# Altium schematic sheet schematic_C.SchDoc(schematic_C)
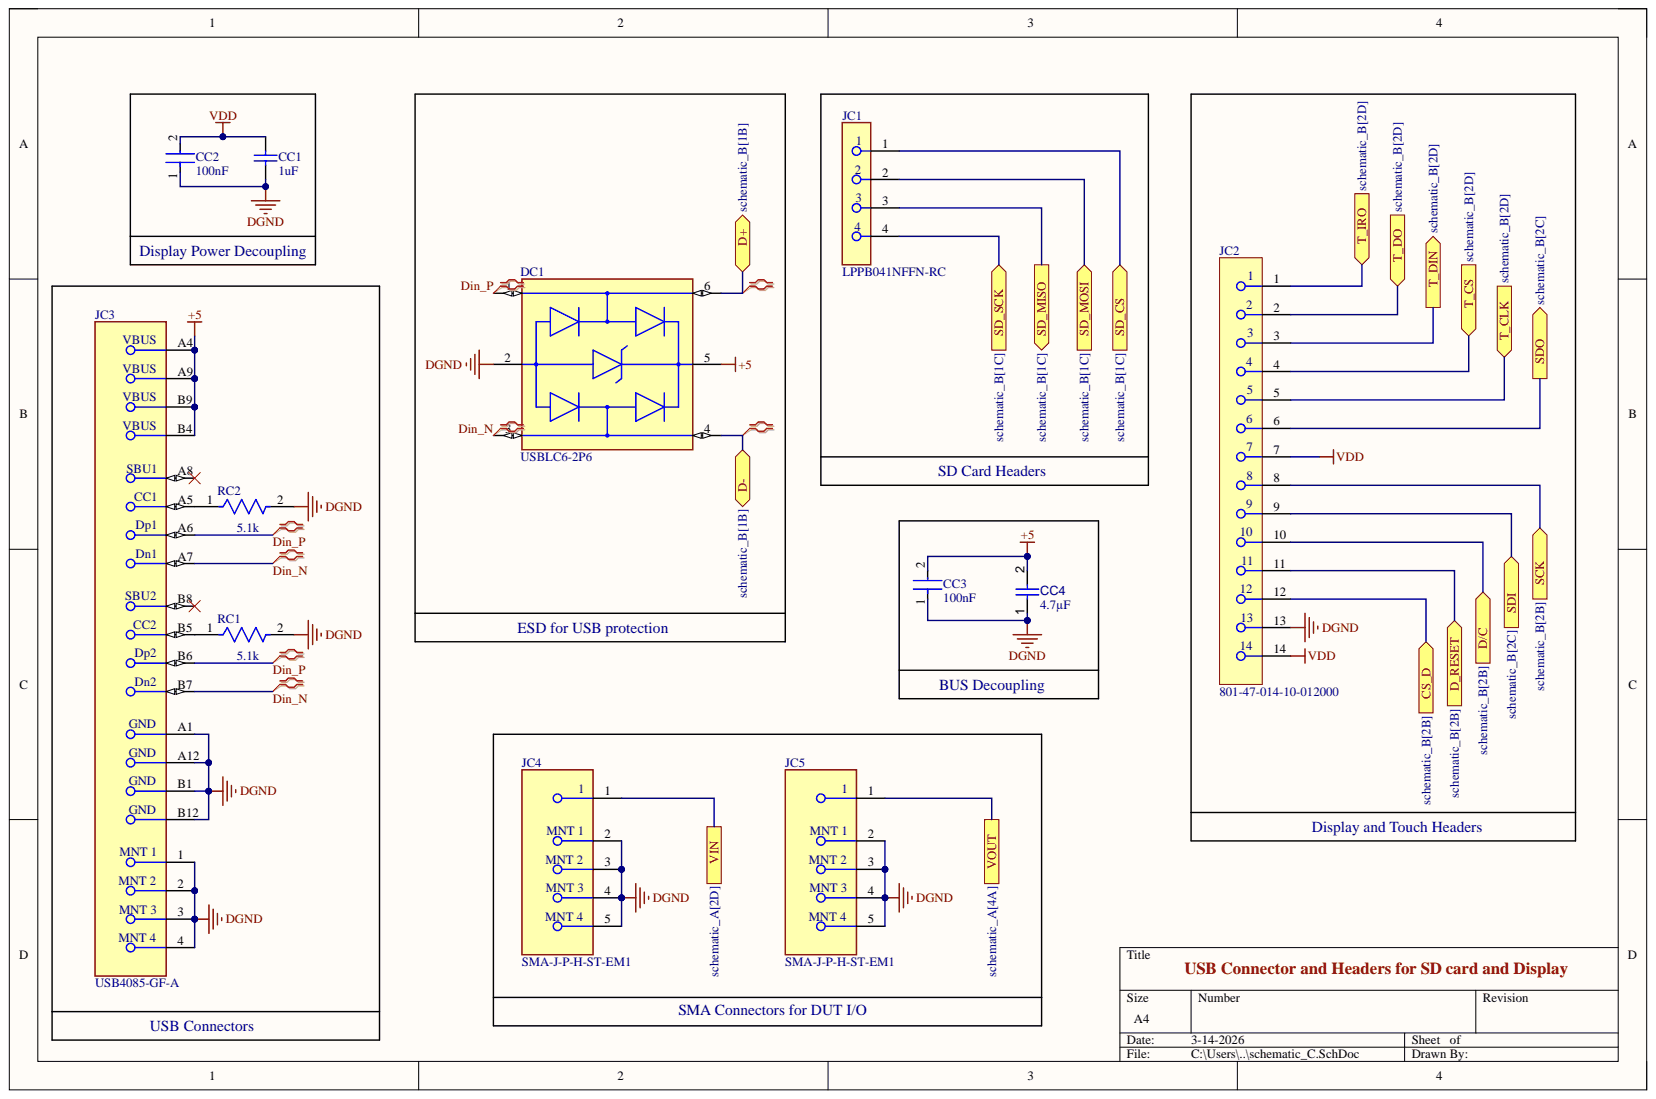
[diagram p0: schematic_C.SchDoc]

## Parts
CC1  1uF  [CAPC0603X33X15NL03T05]
CC2  100nF  [FP-0402-L_1_0_0_05-W_0_5-MFG]
CC3  100nF  [FP-0402-L_1_0_0_05-W_0_5-MFG]
CC4  value 4.7µF  [CAPC0805(2012)145_L]  (hidden comment: Capacitor 4.7µF +/-40% 25V 0805)
DC1  USBLC6-2P6  [FP-SOT-666-MFG]
JC1  LPPB041NFFN-RC  [FP-LPPB041NFFN-RC-MFG]
JC2  801-47-014-10-012000  [801-47-014-10-012000_MFG]
JC3  USB4085-GF-A  [FP-USB4085-GF-A-MFG]
JC4  SMA-J-P-H-ST-EM1  [FP-SMA-J-P-H-ST-EM1-MFG]
JC5  SMA-J-P-H-ST-EM1  [FP-SMA-J-P-H-ST-EM1-MFG]
RC1  5.1k  [KOA_Speer_RN73R1J_MFG]
RC2  5.1k  [KOA_Speer_RN73R1J_MFG]

## Ports
CS_D, D+, D-, D/C, D_RESET, SCK, SD_CS, SD_MISO, SD_MOSI, SD_SCK, SDI, SDO, T_CLK, T_CS, T_DIN, T_DO, T_IRO, VIN, VOUT

## Nets
+5: CC3.2 CC4.2 DC1.5 JC3.A4 JC3.A9 JC3.B4 JC3.B9
DGND: CC1.2 CC2.1 CC3.1 CC4.1 DC1.2 JC2.13 JC3.1 JC3.2 JC3.3 JC3.4 JC3.A1 JC3.A12 JC3.B1 JC3.B12 JC4.2 JC4.3 JC4.4 JC4.5 JC5.2 JC5.3 JC5.4 JC5.5 RC1.2 RC2.2
VDD: CC1.1 CC2.2 JC2.7 JC2.14
CS_D: JC2.12 ; port CS_D
D/C: JC2.10 ; port D/C
D_RESET: JC2.11 ; port D_RESET
Din_N: DC1.3 JC3.A7 JC3.B7
Din_P: DC1.1 JC3.A6 JC3.B6
SCK: JC2.8 ; port SCK
SD_CS: JC1.1 ; port SD_CS
SD_MISO: JC1.3 ; port SD_MISO
SD_MOSI: JC1.2 ; port SD_MOSI
SD_SCK: JC1.4 ; port SD_SCK
SDI: JC2.9 ; port SDI
SDO: JC2.6 ; port SDO
T_CLK: JC2.5 ; port T_CLK
T_CS: JC2.4 ; port T_CS
T_DIN: JC2.3 ; port T_DIN
T_DO: JC2.2 ; port T_DO
T_IRO: JC2.1 ; port T_IRO
VIN: JC4.1 ; port VIN
VOUT: JC5.1 ; port VOUT
NetDC1_4: DC1.4
NetDC1_6: DC1.6
NetJC3_A5: JC3.A5 RC2.1
NetJC3_B5: JC3.B5 RC1.1

Not connected: JC3 A8 B8

## Library data of the parts
CC1 = CMP-2010-00160-1: Manufacturer: AVX Interconnect / Elco; Part Number: 02016D105KAT2A; Component Kind: Standard; Library Name: Altium Content Vault
CC2 CC3 = CMP-14477-003271-3: Ceramic Capacitor General Use 0.1uF ±10% 25V X5R 0402; NexarPartId: 49252556; Capacitance: 100nF; Case/Package: 0402; Component Kind: Standard; Halogen Free: Halogen Free; Height: 550µm; Length: 990.6µm; Library Name: Altium Content Vault; Material: Ceramic; Max Length: 1.05mm; Max Operating Temperature: 85°C; Max Thickness: 550µm; Max Width: 550µm; Min Length: 950µm; Min Operating Temperature: -55°C; Min Thickness: 450µm; Min Width: 450µm; Mount: Surface Mount; Packaging: Tape & Reel (TR); RoHS: Compliant; Thickness: 558.8µm; Tolerance: 10%; Voltage Rating: 25V; Voltage Rating (DC): 25V; Width: 508µm
CC4 = CMP-001-00080-4: Chip Capacitor, 4.7µF +/-40%, 25V, 0805, Thickness 1.45 mm; Tolerance: 20%; Case/Package: 0805; Component Kind: Standard; Library Name: Test; Max Operating Temperature: 85°C; Min Operating Temperature: -55°C; Mounting Technology: SMT; Pins: 2; Voltage Rating: 25V
DC1 = CMP-12225-000058-1: TVS DIODE 5.25V 17V SOT666; Breakdown Voltage: 6V; Capacitance: 3.5pF; Direction: Unidirectional; Element Configuration: Dual; ESD Protection: Yes; Leakage Current: 150nA; Number of Bidirectional Channels: 2; Number of Elements: 1; Operating Supply Voltage: 5V; Peak Pulse Current: 5A; Test Current: 1mA; Case/Package: SOT-666; Clamping Voltage: 17V; Component Kind: Standard; Contact Plating: Tin; Depth: 1.3mm; Height: 0.6mm; Lead Free: Lead Free; Length: 1.7mm; Library Name: Altium Content Vault; Max Breakdown Voltage: 6V; Max Operating Temperature: 125°C; Max Reverse Leakage Current: 150nA; Min Breakdown Voltage: 6V; Min Operating Temperature: -40°C; Mount: Surface Mount; Number of Channels: 2; Number of Pins: 6; Package Quantity: 1; Packaging: Tape and Reel; Polarity: Unidirectional; Power Line Protection: Yes; Radiation Hardening: No; REACH SVHC: No SVHC; Reverse Breakdown Voltage: 6V; Reverse Standoff Voltage: 5V; Termination: SMD/SMT; Width: 1.3mm; Working Voltage: 5V
JC1 = CMP-25680-000035-1: CONN HDR 4POS 0.05 GOLD PCB; Connector Type: Header; Number of Positions: 4; Pitch: 0.05inch; Component Kind: Standard; Contact Plating: Gold; Contact Type: Female Socket; Lead Free: Lead Free; Library Name: Altium Content Vault; Mount: Through Hole; Number of Rows: 1; Package Quantity: 1; Packaging: Bulk; RoHS Compliant: Yes; Termination: Solder
JC2 = CMP-00254-82336594-1: STD SPRING-LOADED CONNECTOR, 14 Pins, Tin over Nickel; Component Kind: Standard; ComponentLink2Description: Datasheet URL; ComponentLink2URL: https://www.mill-max.com/products/datasheet/sockets/801-47-014-10-012000; HelpURL: https://www.mill-max.com/products/datasheet/sockets/801-47-014-10-012000; Library Name: Altium Content Vault; RoHS: Compliant
JC3 = CMP-196119-000001-2: CONN RCPT USB2.0 TYPE C 24POS RA; Gender: Receptacle; Number of Positions: 16; Component Kind: Standard; Contact Material: Copper Alloy; Contact Plating: Gold; Library Name: Altium Content Vault; Orientation: Right Angle; USB Standard: USB 2.0
JC4 JC5 = CMP-02754-000006-1: CONN SMA JACK STR 50OHM EDGE MNT; Body Material: Brass; Body Plating: Gold; Dielectric Material: PTFE; Impedance: 50R; Max Frequency: 6GHz; Voltage Rating: 335V; Case/Package: SMA; Center Contact Plating: Gold; Component Kind: Standard; Connector Type: SMA; Contact Material: Copper; Contact Plating: Gold; Depth: 13.33mm; Fastening Type: Threaded; Housing Color: Gold; Insulation Material: Polytetrafluoroethylene; Length: 6.35mm; Library Name: Altium Content Vault; Max Operating Temperature: 125°C; Min Operating Temperature: -65°C; Mount: Edge; Orientation: Straight; Package Quantity: 1; Packaging: Tray; Radiation Hardening: No; RoHS Compliant: Yes; Series: SMA; Termination: Solder
RC1 RC2 = CMP-00683-99548008-1: RN73R1JTTD5101B25 Res, 5K1, 0.1%, 0.1W, 0603 Rohs Compliant: Yes; Power Rating: 100mW; Resistance: 5.1kΩ; Temperature Coefficient: 25ppm/°C; Voltage Rating: 75V; Ambient Temperature Range High: 85°C; Case/Package: 0603; Component Kind: Standard; Composition: Thin Film; Height: 550µm; Library Name: Altium Content Vault; Max Operating Temperature: 155°C; Min Operating Temperature: -55°C; NexarPartId: 99548008; Tolerance: 0.1%
